# Altium schematic sheet RS485_USB.SchDoc(RS485_USB)
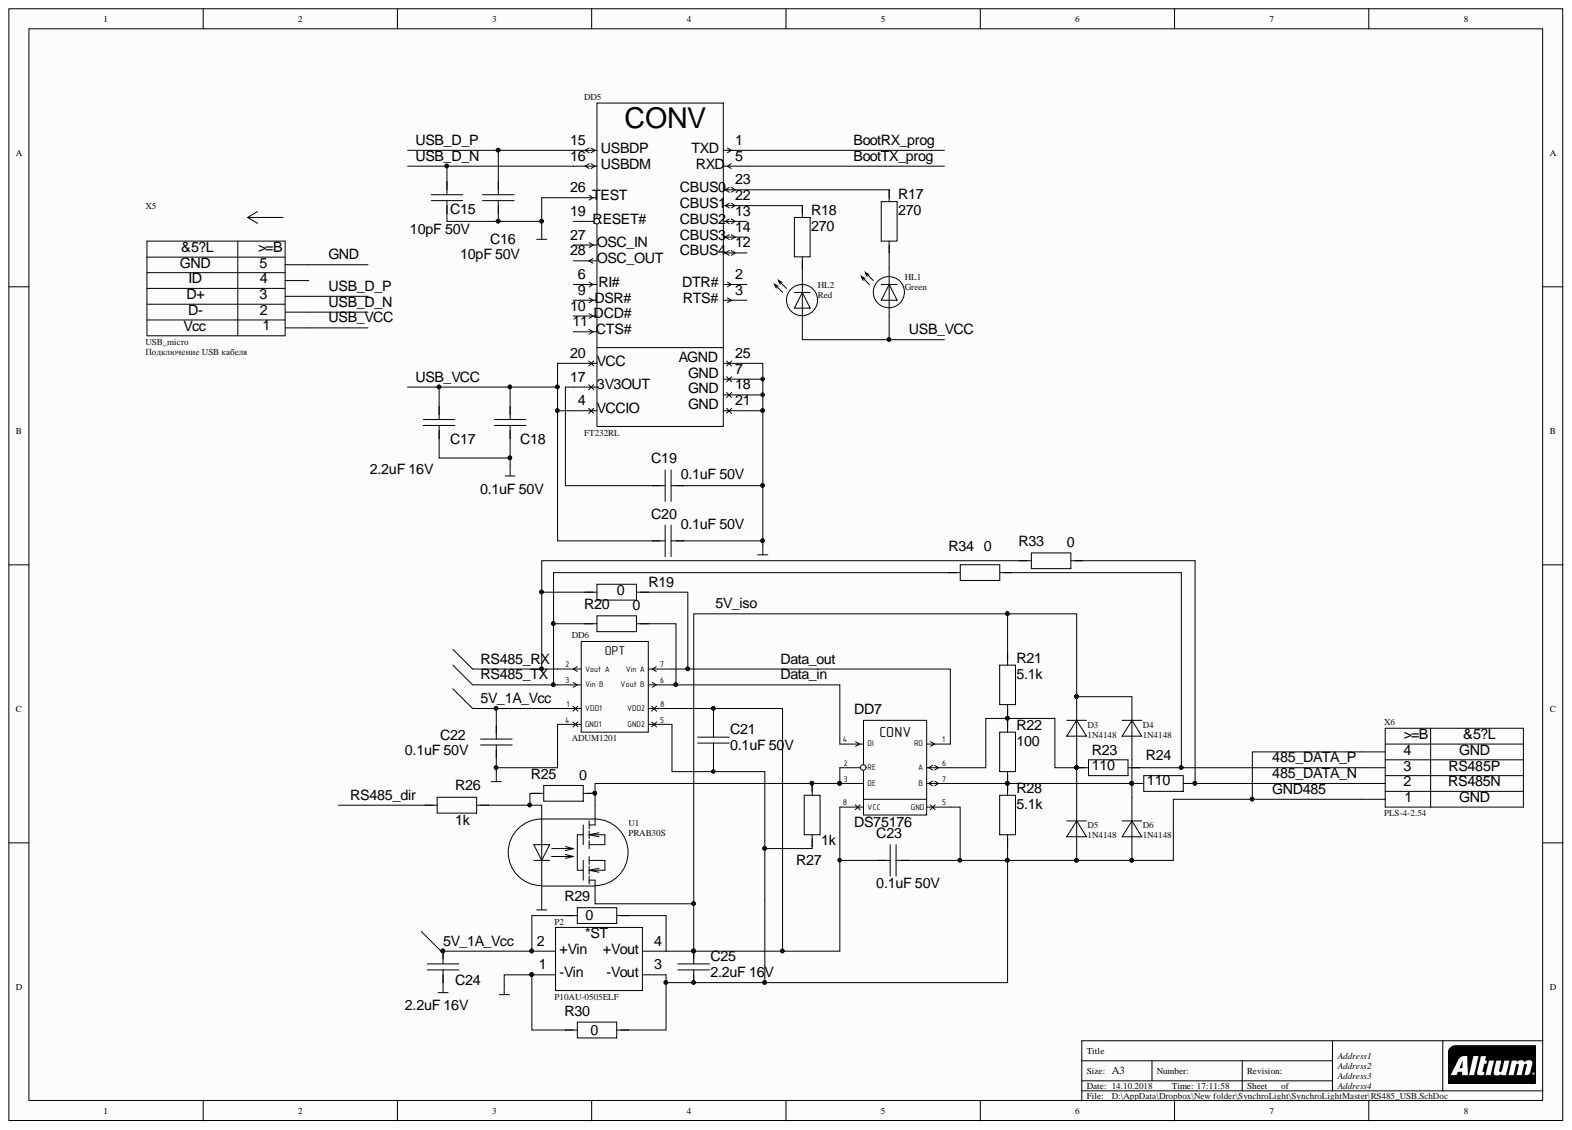
[diagram p0: RS485_USB.SchDoc]

## Parts
C15  10pF 50V  [0805_CAP]
C16  10pF 50V  [0805_CAP]
C17  2.2uF 16V  [0805_CAP]
C18  0.1uF 50V  [0805_CAP]
C19  0.1uF 50V  [0805_CAP]
C20  0.1uF 50V  [0805_CAP]
C21  0.1uF 50V  [0805_CAP]
C22  0.1uF 50V  [0805_CAP]
C23  0.1uF 50V  [0805_CAP]
C24  2.2uF 16V  [0805_CAP]
C25  2.2uF 16V  [0805_CAP]
D3  1N4148  [sod80c]
D4  1N4148  [sod80c]
D5  1N4148  [sod80c]
D6  1N4148  [sod80c]
DD5  FT232RL  [SSOP28]
DD6  ADUM1201  [soic-8]
DD7  DS75176  [soic-8]
HL1  Green  [0603_led_ipc]
HL2  Red  [0603_led_ipc]
P2  P10AU-0505ELF  [SIP4]
R17  270  [0805_RES]
R18  270  [0805_RES]
R19  0  [0805_RES]
R20  0  [0805_RES]
R21  5.1k  [0805_RES]
R22  100  [0805_RES]
R23  110  [0805_RES]
R24  110  [0805_RES]
R25  0  [0805_RES]
R26  1k  [0805_RES]
R27  1k  [0805_RES]
R28  5.1k  [0805_RES]
R29  0  [0805_RES]
R30  0  [0805_RES]
R33  0  [0805_RES]
R34  0  [0805_RES]
U1  PRAB30S  [sop4_optocoup]
X5  USB_micro  Назначение: Подключение USB кабеля  [usb_micro]
X6  PLS-4-2.54  PinName4: GND  PinName3: RS485P  PinName2: RS485N  [PLS-4-2.54]

## Nets
5V_1A_Vcc: C22.1 C24.2 DD6.1(VDD1) P2.2(+Vin) R29.1
5V_iso: C21.1 C23.1 C25.2 D3.2 D4.2 DD6.8(VDD2) DD7.8(VCC) P2.4(+Vout) R21.1 R29.2 U1.3
485_DATA_N: R24.2 R33.2 X6.2
485_DATA_P: R23.2 R34.2 X6.3
BootRX_prog: DD5.1(TXD)
BootTX_prog: DD5.5(RXD)
Data_in: DD6.6(B) DD7.4(DI) R20.2
Data_out: DD6.7(A) DD7.1(RO) R19.2
GND: C15.1 C16.1 C17.1 C18.1 C19.1 C20.1 C22.2 C24.1 DD5.7(GND) DD5.18(GND) DD5.21(GND) DD5.25(AGND) DD5.26 DD6.4(GND1) P2.1(-Vin) R30.1 U1.2 X5.5
GND485: C21.2 C23.2 C25.1 D5.1 D6.1 DD6.5(GND2) DD7.5(GND) P2.3(-Vout) R27.2 R28.2 R30.2 X6.1 X6.4
RS485_dir: R26.1
RS485_RX: DD6.2(Vout) R19.1 R33.1
RS485_TX: DD6.3(Vin) R20.1 R34.1
USB_D_N: C15.2 DD5.16(USBDM) X5.2
USB_D_P: C16.2 DD5.15(USBDP) X5.3
USB_VCC: C17.2 C18.2 C20.2 DD5.4(VCCIO) DD5.20(VCC) HL1.1 HL2.1 X5.1
NetC19_2: C19.2 DD5.17(3V3OUT)
NetD3_1: D3.1 D5.2 DD7.6(A) R21.2 R22.1 R23.1
NetD4_1: D4.1 D6.2 DD7.7(B) R22.2 R24.1 R28.1
NetDD5_22: DD5.22(CBUS1) R18.2
NetDD5_23: DD5.23(CBUS0) R17.2
NetDD7_2: DD7.2(RE) DD7.3(DE) R25.2 R27.1 U1.4
NetHL1_2: HL1.2 R17.1
NetHL2_2: HL2.2 R18.1
NetR25_1: R25.1 R26.2 U1.1

Not connected: DD5 2(DTR#) 3(RTS#) 6(RI#) 9(DSR#) 10(DCD#) 11(CTS#) 12(CBUS4) 13(CBUS2) 14(CBUS3) 19 27(OSC_IN) 28(OSC_OUT); X5 4

## Library data of the parts
C15-C25 = Cap_np: Component Kind: Standard; Library Name: Integrated_Library_tikhovikt.IntLib
  C15 C16: Value: 10pF 50V
  C17 C24 C25: Value: 2.2uF 16V
  C18-C23: Value: 0.1uF 50V
D3-D6 = Diode: Value: 1N4148; Component Kind: Standard; Library Name: Integrated_Library_tikhovikt.IntLib
HL1 HL2 = led: Component Kind: Standard; Library Name: Integrated_Library_tikhovikt.IntLib
  HL1: Value: Green
  HL2: Value: Red
P2 = P10AU: Value: P10AU-0505ELF; Component Kind: Standard; Library Name: Integrated_Library_tikhovikt.IntLib
R17-R30 R33 R34 = Res: Component Kind: Standard; Library Name: Integrated_Library_tikhovikt.IntLib
  R17 R18: Value: 270
  R19 R20 R25 R29 R30 R33 R34: Value: 0
  R21 R28: Value: 5.1k
  R22: Value: 100
  R23 R24: Value: 110
  R26 R27: Value: 1k
U1 = Opto_Realy: Value: PRAB30S; Component Kind: Standard; Library Name: Integrated_Library_tikhovikt.IntLib
X6 = 4pin_conn: Destiny: Назначение разъема; Value: PLS-4-2.54; PinName1: GND; Component Kind: Standard; Library Name: Integrated_Library_tikhovikt.IntLib
DD5 = FT232RL: Value: FT232RL; Component Kind: Standard; Library Name: Integrated_Library_tikhovikt.IntLib
DD6 = ADUM1201: Value: ADUM1201; Component Kind: Standard; Library Name: Integrated_Library_tikhovikt.IntLib
DD7 = ds75176: Value: DS75176; Component Kind: Standard; Library Name: Integrated_Library_tikhovikt.IntLib
X5 = USB_micro: Value: USB_micro; Component Kind: Standard; Library Name: Integrated_Library_tikhovikt.IntLib
